# Altium schematic sheet LAN.SchDoc(LAN)
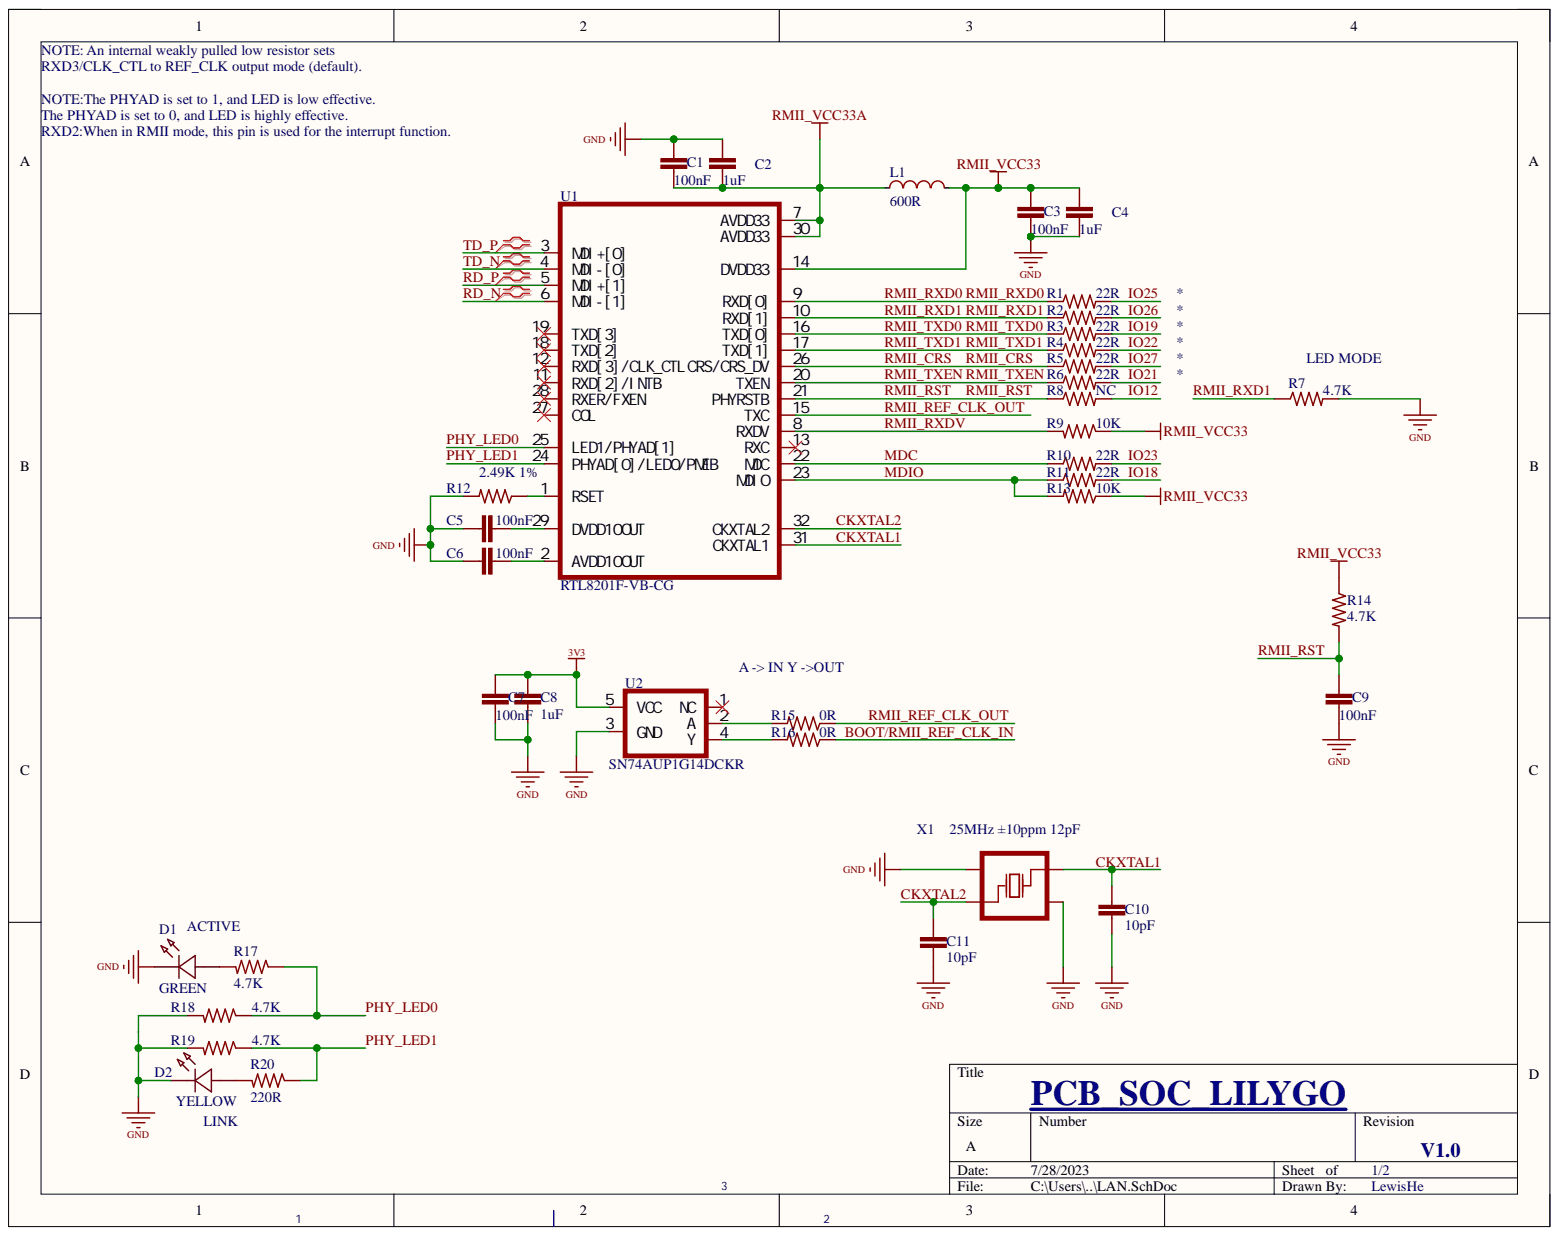
[diagram p0: LAN.SchDoc]

## Parts
C1  100nF  [C0402]
C2  1uF  [C0402]
C3  100nF  [C0402]
C4  1uF  [C0402]
C5  100nF  [C0402]
C6  100nF  [C0402]
C7  100nF  [C0402]
C8  1uF  [C0402]
C9  100nF  [C0402]
C10  10pF  [C0402]
C11  10pF  [C0402]
D1  GREEN  [LED0603_RED]
D2  YELLOW  [LED0603_RED]
L1  600R  [L0402]
R1  22R  [R0402]
R2  22R  [R0402]
R3  22R  [R0402]
R4  22R  [R0402]
R5  22R  [R0402]
R6  22R  [R0402]
R7  4.7K  [R0402]
R8  NC  [R0402]
R9  10K  [R0402]
R10  22R  [R0402]
R11  22R  [R0402]
R12  2.49K 1%  [R0402]
R13  10K  [R0402]
R14  4.7K  [R0402]
R15  0R  [R0402]
R16  0R  [R0402]
R17  4.7K  [R0402]
R18  4.7K  [R0402]
R19  4.7K  [R0402]
R20  220R  [R0402]
U1  RTL8201F-VB-CG  [QFN-32_L5.0-W5.0-P0.50-BL-EP3.4 - duplicate1]
U2  SN74AUP1G14DCKR  [SC-70-5_L2.1-W1.3-P0.65-LS2.1-BL]
X1  25MHz ±10ppm 12pF  [CRYSTAL-SMD_4P-L3.2-W2.5-BL]

## Nets
3V3: C7.2 C8.2 U2.5(VCC)
GND: C1.2 C2.2 C3.1 C4.1 C5.1 C6.1 C7.1 C8.1 C9.1 C10.2 C11.1 D1.2 D2.2 R7.2 R12.2 R18.2 R19.2 U1.33 U2.3(GND) X1.2 X1.4
RMII_VCC33: C3.2 C4.2 L1.2 R9.1 R13.1 R14.1 U1.14(DVDD33)
RMII_VCC33A: C1.1 C2.1 L1.1 U1.7(AVDD33) U1.30(AVDD33)
BOOT/RMII_REF_CLK_IN: R16.1
CKXTAL1: C10.1 U1.31(CKXTAL1) X1.3
CKXTAL2: C11.2 U1.32(CKXTAL2) X1.1
IO12: R8.2
IO18: R11.2
IO19: R3.2
IO21: R6.2
IO22: R4.2
IO23: R10.2
IO25: R1.2
IO26: R2.2
IO27: R5.2
MDC: R10.1 U1.22(MDC)
MDIO: R11.1 R13.2 U1.23(MDIO)
PHY_LED0: R17.1 R18.1 U1.25(LED1/PHYAD[1])
PHY_LED1: R19.1 R20.1 U1.24(PHYAD[0]/LED0/PMEB)
RD_N: U1.6(MDI-[1])
RD_P: U1.5(MDI+[1])
RMII_CRS: R5.1 U1.26(CRS/CRS_DV)
RMII_REF_CLK_OUT: R15.1 U1.15(TXC)
RMII_RST: C9.2 R8.1 R14.2 U1.21(PHYRSTB)
RMII_RXD0: R1.1 U1.9(RXD[0])
RMII_RXD1: R2.1 R7.1 U1.10(RXD[1])
RMII_RXDV: R9.2 U1.8(RXDV)
RMII_TXD0: R3.1 U1.16(TXD[0])
RMII_TXD1: R4.1 U1.17(TXD[1])
RMII_TXEN: R6.1 U1.20(TXEN)
TD_N: U1.4(MDI-[0])
TD_P: U1.3(MDI+[0])
NetC5_2: C5.2 U1.29(DVDD10OUT)
NetC6_2: C6.2 U1.2(AVDD10OUT)
NetD1_1: D1.1 R17.2
NetD2_1: D2.1 R20.2
NetR12_1: R12.1 U1.1(RSET)
NetR15_2: R15.2 U2.2(A)
NetR16_2: R16.2 U2.4(Y)

Not connected: U1 11(RXD[2]/INTB) 12(RXD[3]/CLK_CTL) 13(RXC) 18(TXD[2]) 19(TXD[3]) 27(COL) 28(RXER/FXEN); U2 1(NC)

## Library data of the parts
C1-C11 = C0201: 0201  贴片电容; Component Kind: Standard; Library Name: 常用.SCHLIB
D1 D2 = LED0603: Component Kind: Standard; Description: LED0603; Library Name: 常用.SCHLIB
L1 = L0402: Component Kind: Standard; Description: L0402; Library Name: 常用.SCHLIB
R1-R20 = R0201: Component Kind: Standard; Library Name: 常用.SCHLIB
U1 = SR8201F: Component Kind: Standard; ComponentLink1Description: 立创链接; ComponentLink1URL: https://item.szlcsc.com/351626.html; Library Name: 常用.SCHLIB
X1 = X1E000021013900: 晶振类型:贴片无源晶振;频率:25MHz;频率公差:±10ppm;负载电容:12pF;等效串联电阻(ESR):40Ω;工作温度:-40℃~+85℃;; Component Kind: Standard; Library Name: 常用.SCHLIB
U2 = SN74AUP1G14DCKR: Component Kind: Standard; ComponentLink1Description: 立创链接; ComponentLink1URL: https://item.szlcsc.com/2776350.html; Library Name: 常用.SCHLIB
